# Altium schematic sheet Э3("All Documents",Physical)
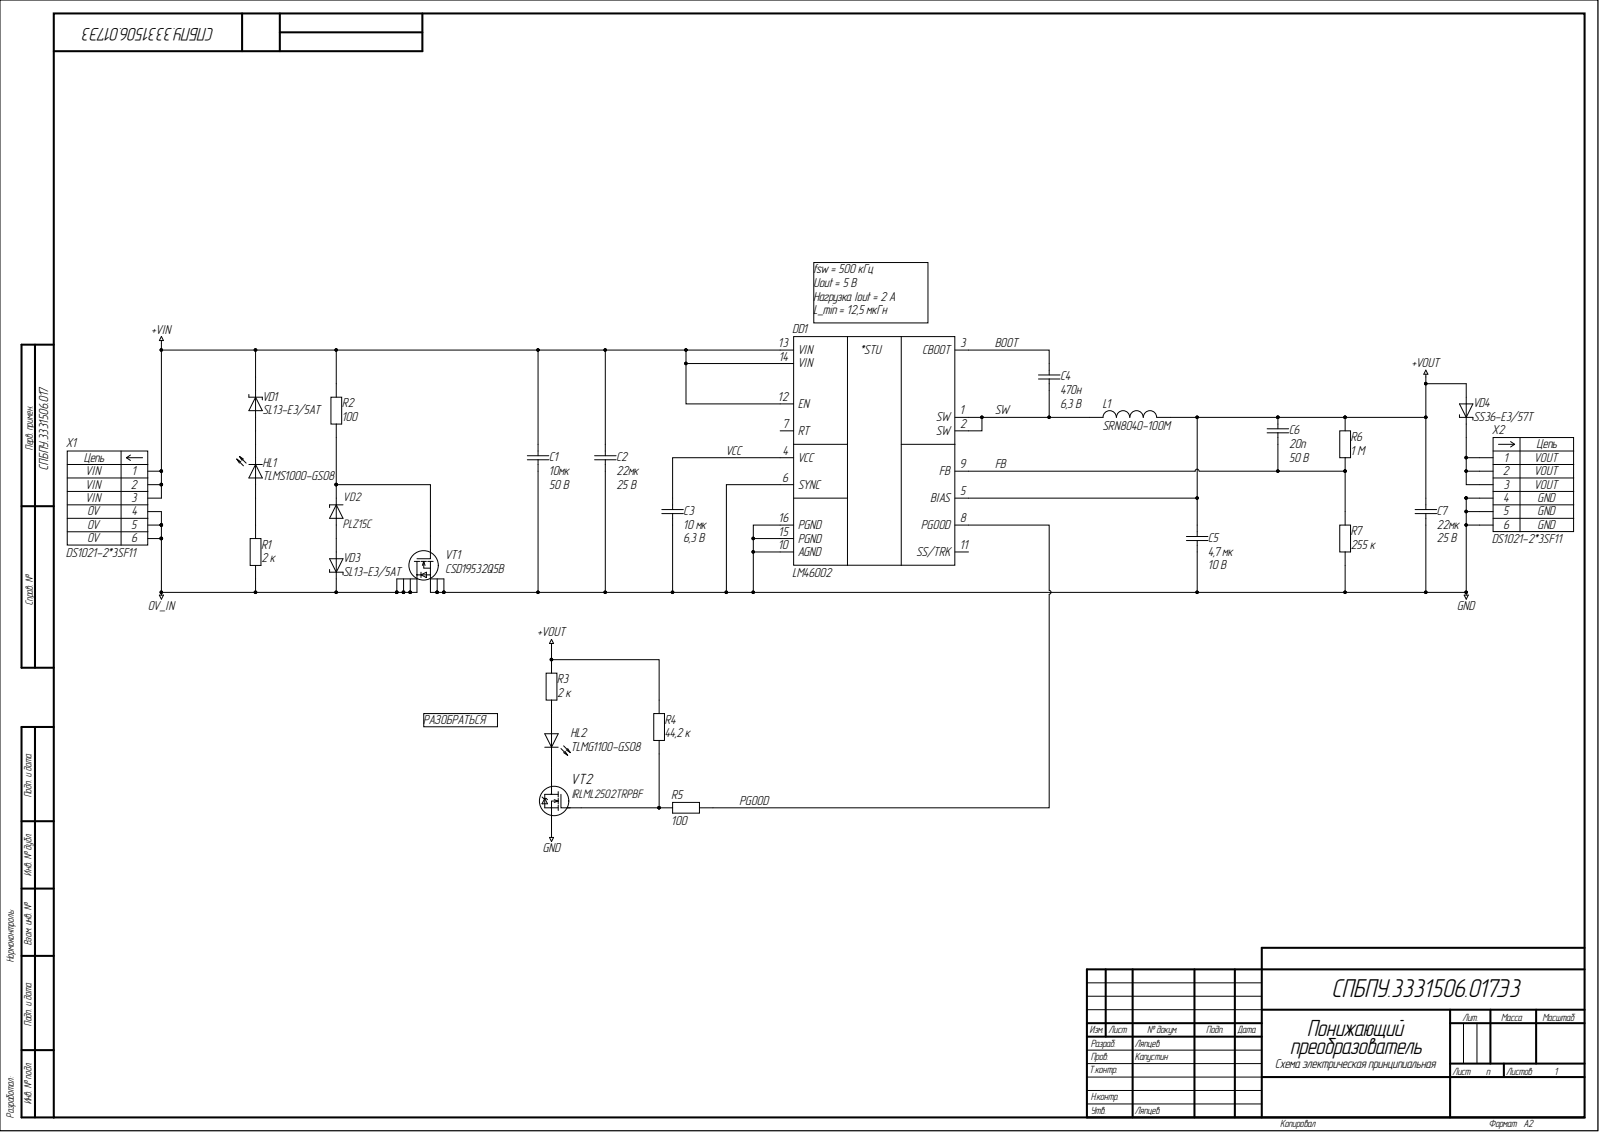
[diagram p0: Э3("All Documents",Physical)]

## Parts
C1  10мк  Номинальное напряжение: 50 В  [C1210]
C2  22мк  Номинальное напряжение: 25 В  [C1210]
C3  10 мк  Номинальное напряжение: 6,3 В  [C0603]
C4  470н  [C0402]
C5  4,7 мк  Номинальное напряжение: 10 В  [C0805]
C6  20п  Номинальное напряжение: 50 В  [C0402]
C7  22мк  Номинальное напряжение: 25 В  [C1210]
DD1  LM46002  [HTSSOP-16]
HL1  TLMS1000-GS08  [HL0603]
HL2  TLMG1100-GS08  [HL0603]
L1  SRN8040-100M  [SRN-2-815X790X400]
R1  2 к  [R0603]
R2  100  [R0603]
R3  2 к  [R0603]
R4  44,2 к  [R0603]
R5  100  [R0603]
R6  1 М  [R0603]
R7  255 к  [R0603]
VD1  SL13-E3/5AT  [DO-214AC]
VD2  PLZ15C  [DO-219AC]
VD3  SL13-E3/5AT  [DO-214AC]
VD4  SS36-E3/57T  [DO-214AB]
VT1  CSD19532Q5B  [SON-8]
VT2  IRLML2502TRPBF  [SOT23-3]
X1  DS1021-2*3SF11  Contact_6: 0V  Contact_3: VIN  [DS1021-2X3SF11]
X2  DS1021-2*3SF11  Contact_6: GND  Contact_3: VOUT  [DS1021-2X3SF11]

## Nets
0V_IN: R1.1 VD3.1 VT1.D X1.4 X1.5 X1.6
+VIN: C1.1 C2.1 DD1.12(EN) DD1.13(VIN) DD1.14(VIN) R2.2 VD1.1 X1.1 X1.2 X1.3
+VOUT: C5.1 C6.1 C7.1 DD1.5(BIAS) L1.2 R3.2 R4.2 R6.2 VD4.2
GND: C1.2 C2.2 C3.2 C5.2 C7.2 DD1.6(SYNC) DD1.10(AGND) DD1.15(PGND) DD1.16(PGND) R7.1 VT1.S VT2.1 X2.4 X2.5 X2.6
BOOT: C4.1 DD1.3(CBOOT)
FB: C6.2 DD1.9(FB) R6.1 R7.2
PGOOD: DD1.8(PGOOD) R5.2
SW: C4.2 DD1.1(SW) DD1.2(SW) L1.1
VCC: C3.1 DD1.4(VCC)
NetDD1_7: DD1.7(RT)
NetDD1_11: DD1.11(SS/TRK)
NetHL1_1: HL1.1 VD1.2
NetHL1_2: HL1.2 R1.2
NetHL2_1: HL2.1 VT2.2
NetHL2_2: HL2.2 R3.1
NetR2_1: R2.1 VD2.1 VT1.G
NetR4_1: R4.1 R5.1 VT2.3
NetVD2_2: VD2.2 VD3.2
NetVD4_1: VD4.1 X2.1 X2.2 X2.3

## Library data of the parts
C1 = с0036: =Описание; Фамилия: Ляпцев; Рабочие температуры: от -55 °С до +125 °С; Производитель: MuRata; Отклонение: ±15 %; Описание: Конденсатор чип 1210 10 мкФ 50 В ±15 %; Наименование: GRM32ER71H106KA12L; Корпус: 1210; Database Table Name: Конденсаторы$; Номинал: 10мк; Напряжение: 50 В; ID: с0036; HelpURL: https://search.murata.co.jp/Ceramy/image/img/A01X/G101/ENG/GRM32ER71H106KA12-04A.pdf; Description: Конденсатор чип 1210 10 мкФ 50 В ±15 %; Component Kind: Standard; Library Name: Database_Students_v1.DbLib
C2 = с0035: =Описание; Фамилия: Ляпцев; Рабочие температуры: от -55 °С до +125 °С; Производитель: YAGEO Corp.; Отклонение: ±15 %; Описание: Конденсатор чип 1210 22 мкФ 25 В ±15 %; Наименование: CC1210KKX7R8BB226; Корпус: 1210; Database Table Name: Конденсаторы$; Номинал: 22мк; Напряжение: 25 В; ID: с0035; HelpURL: https://www.yageo.com/upload/media/product/productsearch/datasheet/mlcc/UPY-GPHC_X7R_6.3V-to-50V_20.pdf; Description: Конденсатор чип 1210 22 мкФ 25 В ±15 %; Component Kind: Standard; Library Name: Database_Students_v1.DbLib
C3 = с0040: =Описание; Фамилия: Ляпцев; Рабочие температуры: от -55 °С до +85 °С; Производитель: YAGEO Corp.; Отклонение: ±20 %; Описание: Конденсатор чип 0603 10 мкФ 6,3 В ±20 %; Наименование: CC0603MRX5R5BB106; Корпус: 0603; Database Table Name: Конденсаторы$; Номинал: 10 мк; Напряжение: 6,3 В; ID: с0040; HelpURL: https://www.yageo.com/upload/media/product/productsearch/datasheet/mlcc/UPY-GPHC_X5R_4V-to-50V_26.pdf; Description: Конденсатор чип 0603 10 мкФ 6,3 В ±20 %; Component Kind: Standard; Library Name: Database_Students_v1.DbLib
C4 = с0039: =Описание; Фамилия: Ляпцев; Рабочие температуры: от -55 °С до +85 °С; Производитель: MuRata; Отклонение: ±15 %; Описание: Конденсатор чип 0402 470 нФ 6,3 В ±15 %; Номинальное напряжение: 6,3 В; Наименование: GRM155R60J474KE19D; Корпус: 0402; Database Table Name: Конденсаторы$; Номинал: 470н; Напряжение: 6,3 В; ID: с0039; HelpURL: https://www.murata.com/en-sg/products/productdetail?partno=GRM155R60J474KE19%23; Description: Конденсатор чип 0402 470 нФ 6,3 В ±15 %; Component Kind: Standard; Library Name: Database_Students_v1.DbLib
C5 = с0041: =Описание; Фамилия: Ляпцев; Рабочие температуры: от -55 °С до +85 °С; Производитель: MuRata; Отклонение: ±15 %; Описание: Конденсатор чип 0805 4,7 мкФ 10 В ±15 %; Наименование: GRM219R61A475KE34; Корпус: 805; Database Table Name: Конденсаторы$; Номинал: 4,7 мк; Напряжение: 10 В; ID: с0041; HelpURL: https://www.murata.com/en-global/products/productdetail?partno=GRM219R61A475KE34%23; Description: Конденсатор чип 0805 4,7 мкФ 10 В ±15 %; Component Kind: Standard; Library Name: Database_Students_v1.DbLib
C6 = с0038: =Описание; Фамилия: Ляпцев; Рабочие температуры: от -55 °С до +85 °С; Производитель: YAGEO Corp.; Отклонение: ±5 %; Описание: Конденсатор чип 0402 20 пФ 25 В ±5 %; Наименование: CC0402JRNPO9BN200; Корпус: 0402; Database Table Name: Конденсаторы$; Номинал: 20п; Напряжение: 50 В; ID: с0038; HelpURL: https://www.yageo.com/upload/media/product/productsearch/datasheet/mlcc/UPY-GP_NP0_16V-to-50V_18.pdf; Description: Конденсатор чип 0402 20 пФ 25 В ±5 %; Component Kind: Standard; Library Name: Database_Students_v1.DbLib
C7 = с0037: =Описание; Фамилия: Ляпцев; Рабочие температуры: от -55 °С до +125 °С; Производитель: MuRata; Отклонение: ±15 %; Описание: Конденсатор чип 1210 22 мкФ 25 В ±15 %; Наименование: GRM32ER61E226KE15L; Корпус: 1210; Database Table Name: Конденсаторы$; Номинал: 22мк; Напряжение: 25 В; ID: с0037; HelpURL: https://search.murata.co.jp/Ceramy/image/img/A01X/G101/ENG/GRM32ER61E226KE15-01.pdf; Description: Конденсатор чип 1210 22 мкФ 25 В ±15 %; Component Kind: Standard; Library Name: Database_Students_v1.DbLib
DD1 = dd0006: =Описание; Частота переключения: от 200 кГц до 2,2 МГц; Фамилия: Ляпцев; Рабочие температуры: от -40 °С до +125 °С; Производитель: Texas Instruments; Описание: Понижающий преобразователь напряжения 60 В и 2 А; Коммутируемый ток: до 2 А; Выходное напряжение: от 1,011 В до 28 В; Входное напряжение: от 3,5 В до 60 В; Database Table Name: Преобразователи напряжения$; Наименование: LM46002; Корпус: HTSSOP-16; ID: dd0006; HelpURL: https://www.ti.com/lit/ds/symlink/lm46002.pdf?ts=1606573990094&ref_url=https%253A%252F%252Fwww.ti.com%252Fproduct%252FLM46002; Description: Понижающий преобразователь напряжения 60 В и 2 А; Component Kind: Standard; Library Name: Database_Students.DbLib
HL1 = hl0001: =Описание; Цвет: Red; Фамилия: Ларионов; Рассеиваемая мощность: 40 мВт; Рабочие температуры: от -40 °С до +100 °С; Производитель: Vishay Intertechnology, Inc.; Описание: Светодиод чип 0603 красный; Номинальный прямой ток: 15 мА; Номинальное падение напряжения: 1,8 В; Номинальная сила света: 4 мкд; Номинальная длина волны: 628 нм; Корпус: 0603; Database Table Name: Светодиоды$; Наименование: TLMS1000-GS08; ID: hl0001; HelpURL: https://www.vishay.com/leds/list/product-83172/; Description: Светодиод чип 0603 красный; Component Kind: Standard; Library Name: Database_Students.DbLib
HL2 = hl0005: =Описание; Цвет: Green; Фамилия: Ларионов; Рассеиваемая мощность: 90 мВт; Рабочие температуры: от -40 °С до +100 °С; Производитель: Vishay Intertechnology, Inc.; Описание: Светодиод чип 0603 зеленый; Номинальный прямой ток: 30 мА; Номинальное падение напряжения: 2,1 В; Номинальная сила света: 35 мкд; Номинальная длина волны: 570 нм; Корпус: 0603; Database Table Name: Светодиоды$; Наименование: TLMG1100-GS08; ID: hl0005; HelpURL: https://www.vishay.com/leds/list/product-83173/; Description: Светодиод чип 0603 зеленый; Component Kind: Standard; Library Name: Database_Students.DbLib
L1 = I0003: =Описание; Частота резонанса: 2,52 МГц; Фамилия: Ляпцев; Ток насыщения: 3,4 А; Рабочие температуры: от -40 °С до +125 °С; Рабочее напряжение: не указано; Производитель: Bourns, Inc.; Отклонение: ±20 %; Описание: Индуктивность 10 мкГн ±20 %; Номинальный ток (при нагреве на 20 °С/40 °С): 3,1 А; Номинальное активное сопротивление: 50 мОм; Номинал: 10 мкГн; Максимальное активное сопротивление: 0,05 Ом; Корпус: 8,15 х 7,9 х 4; Database Table Name: Индуктивности$; Наименование: SRN8040-100M; ID: I0003; HelpURL: https://www.bourns.com/data/global/pdfs/SRN8040.pdf; Description: Индуктивность 10 мкГн ±20 %; Component Kind: Standard; Library Name: Database_Students_v1.DbLib
R1 R3 = r0014: =Описание; Фамилия: Ларионов; Рабочие температуры: от -55 °С до +155 °С; Производитель: YAGEO Corp.; Отклонение: ±1 %; Описание: Резистор чип 0603 2 кОм ±1 % 0,1 Вт; Номинальный ток: 1 А; Номинальное напряжение: 75 В; Наименование: RC0603FR-072KL; Корпус: 0603; Database Table Name: Резисторы$; Номинал: 2 к; ID: r0014; HelpURL: https://www.yageo.com/upload/media/product/productsearch/datasheet/rchip/PYu-RC_Group_51_RoHS_L_11.pdf; Description: Резистор чип 0603 2 кОм ±1 % 0,1 Вт; Component Kind: Standard; Library Name: Database_Students.DbLib
R2 R5 = r0007: =Описание; Фамилия: Самарин; Рабочие температуры: от -55 °С до +155 °С; Производитель: YAGEO Corp.; Отклонение: ±1 %; Описание: Резистор чип 0603 100 Ом ±1 % 0,1 Вт; Номинальный ток: 1 А; Номинальное напряжение: 75 В; Наименование: RC0603FR‍‐07100RL; Корпус: 0603; Database Table Name: Резисторы$; Номинал: 100; ID: r0007; HelpURL: https://www.yageo.com/upload/media/product/productsearch/datasheet/rchip/PYu-RC_Group_51_RoHS_L_11.pdf; Description: Резистор чип 0603 100 Ом ±1 % 0,1 Вт; Component Kind: Standard; Library Name: Database_Students.DbLib
R4 = r0026: =Описание; Фамилия: Ларионов; Рабочие температуры: от -55 °С до +155 °С; Производитель: YAGEO Corp.; Отклонение: ±1 %; Описание: Резистор чип 0603 44,2 кОм ±1 % 0,1 Вт; Номинальный ток: 1 А; Номинальное напряжение: 75 В; Наименование: RC0603FR-0744K2L; Корпус: 0603; Database Table Name: Резисторы$; Номинал: 44,2 к; ID: r0026; HelpURL: https://www.yageo.com/upload/media/product/productsearch/datasheet/rchip/PYu-RC_Group_51_RoHS_L_11.pdf; Description: Резистор чип 0603 44,2 кОм ±1 % 0,1 Вт; Component Kind: Standard; Library Name: Database_Students.DbLib
R6 = r0038: =Описание; Фамилия: Ляпцев; Рабочие температуры: от -55 °С до +155 °С; Производитель: YAGEO Corp.; Отклонение: ±1 %; Описание: Резистор чип 0603 1 МОм ±1 % 75 В; Номинальный ток: 1 А; Номинальное напряжение: 75 В; Наименование: RC0603FR-071ML; Корпус: 0603; Database Table Name: Резисторы$; Номинал: 1 М; ID: r0038; HelpURL: https://www.yageo.com/upload/media/product/productsearch/datasheet/rchip/PYu-RC_Group_51_RoHS_L_11.pdf; Description: Резистор чип 0603 1 МОм ±1 % 75 В; Component Kind: Standard; Library Name: Database_Students.DbLib
R7 = r0039: =Описание; Фамилия: Ляпцев; Рабочие температуры: от -55 °С до +155 °С; Производитель: YAGEO Corp.; Отклонение: ±1 %; Описание: Резистор чип 0603 255 кОм ±1 % 75 В; Номинальный ток: 1 А; Номинальное напряжение: 75 В; Наименование: RC0603FR-07255KL; Корпус: 0603; Database Table Name: Резисторы$; Номинал: 255 к; ID: r0039; HelpURL: https://www.yageo.com/upload/media/product/productsearch/datasheet/rchip/PYu-RC_Group_51_RoHS_L_11.pdf; Description: Резистор чип 0603 255 кОм ±1 % 75 В; Component Kind: Standard; Library Name: Database_Students.DbLib
VD1 VD3 = vd0001: =Описание; Фамилия: Ларионов; Рабочие температуры: от -55 °С до +125 °С; Производитель: Vishay Intertechnology, Inc.; Пиковое прямое напряжение: 0,445 В; Описание: Диод Шоттки 30 В 1,5 А; Макс допустимый средний прямой ток: 1,5 А; Импульсное обратное напряжение: 30 В; Database Table Name: Диоды$; Наименование: SL13-E3/5AT; Корпус: DO-214AC; ID: vd0001; HelpURL: https://www.vishay.com/product?docid=88740; Description: Диод Шоттки 30 В 1,5 А; Component Kind: Standard; Library Name: Database_Students.DbLib
VD2 = vd0003: =Описание; Фамилия: Ляпцев; Рабочие температуры: от -40 до + 150; Производитель: Vishay Intertechnology, Inc.; Пиковое прямое напряжение: 15В; Описание: Стабилитрон 15В; Database Table Name: Стабилитроны$; Наименование: PLZ15C; Корпус1: DO-219AC; Корпус: DO-219AC; ID: vd0003; Description: Стабилитрон 15В; Component Kind: Standard; Library Name: Database_Students_v1.DbLib
VD4 = vd0003: =Описание; Фамилия: Жернаков; Рабочие температуры: от -55 °С до +150 °С; Производитель: Vishay Intertechnology, Inc.; Пиковое прямое напряжение: 0,75 В; Описание: Диод Шоттки 60 В 3 А; Макс допустимый средний прямой ток: 3 А; Импульсное обратное напряжение: 60 В; Database Table Name: Диоды$; Наименование: SS36-E3/57T; Корпус: DO-214AB; ID: vd0003; HelpURL: https://www.vishay.com/product?docid=88751; Description: Диод Шоттки 60 В 3 А; Component Kind: Standard; Library Name: Database_Students.DbLib
VT1 = vt0001: =Описание; Фамилия: Жернаков; Ток стока: 17 А; Сопротивление сток-исток: 0,0057 Ом; Рабочие температуры: от -55 °С до +150 °С; Производитель: Texas Instruments; Описание: Транзистор полевой N-канальный 100 В 17 А; Напряжение сток-исток: 100 В; Напряжение затвор-исток: ±20 В; Database Table Name: Транзисторы$; Наименование: CSD19532Q5B; Корпус: SON-8; ID: vt0001; HelpURL: https://www.ti.com/product/CSD19532Q5B?keyMatch=CSD19532Q5B&tisearch=Search-EN-everything&usecase=OPN; Description: Транзистор полевой N-канальный 100 В 17 А; Component Kind: Standard; Library Name: Database_Students.DbLib
VT2 = tt0002: =Описание; Фамилия: Ляпцев; Производитель: International Rectifier; Описание: Транзистор полевой N-канальный; Database Table Name: Транзисторы$; Наименование: IRLML2502TRPBF; ID: tt0002; Description: Транзистор полевой N-канальный; Component Kind: Standard; Library Name: Database_Students_v1.DbLib
X1 X2 = x0005: =Описание; Фамилия: Самарин; Производитель: Connfly Electronic Co. LTD.; Описание: PLS 3x2 pin 2,54 мм вилка прямой; Database Table Name: Разъемы$; Наименование: DS1021-2*3SF11; ID: x0005; HelpURL: http://www.connfly.com/productshow.aspx?id=118; Description: PLS 3x2 pin 2,54 мм вилка прямой; Component Kind: Standard; Library Name: Database_Students.DbLib
  X1: Contact_5: 0V; Contact_4: 0V; Contact_2: VIN; Contact_1: VIN
  X2: Contact_5: GND; Contact_4: GND; Contact_2: VOUT; Contact_1: VOUT
